# Altium schematic sheet MEHCP.SchDoc
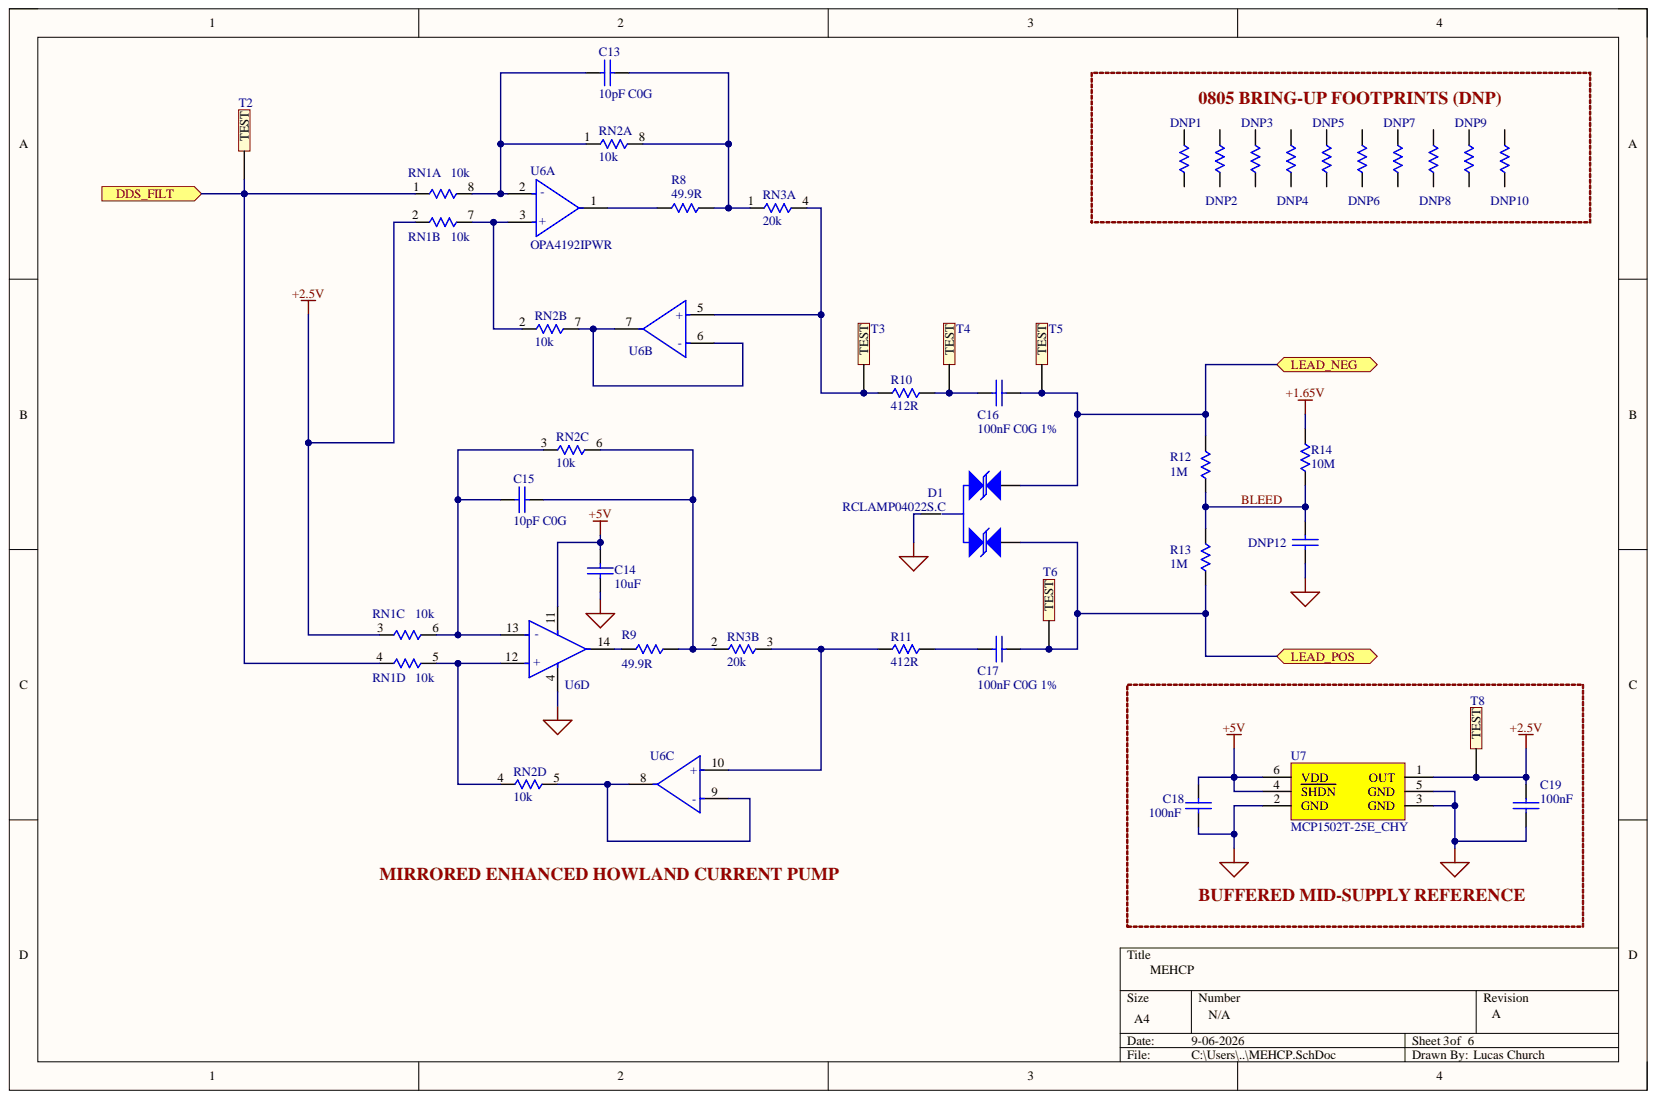
[diagram p0: MEHCP.SchDoc]

## Parts
C13  10pF C0G  [0603-CAP]
C14  10uF  [0603-CAP]
C15  10pF C0G  [0603-CAP]
C16  100nF C0G 1%  [1206-CAP]
C17  100nF C0G 1%  [1206-CAP]
C18  100nF  [0603-CAP]
C19  100nF  [0603-CAP]
D1  RCLAMP04022S.C  [SOT-23 3L]
DNP1  240R 0.1%  [0805-RES]  (value not drawn)  (no BOM)
DNP2  240R 0.1%  [0805-RES]  (value not drawn)  (no BOM)
DNP3  240R 0.1%  [0805-RES]  (value not drawn)  (no BOM)
DNP4  240R 0.1%  [0805-RES]  (value not drawn)  (no BOM)
DNP5  240R 0.1%  [0805-RES]  (value not drawn)  (no BOM)
DNP6  240R 0.1%  [0805-RES]  (value not drawn)  (no BOM)
DNP7  240R 0.1%  [0805-RES]  (value not drawn)  (no BOM)
DNP8  240R 0.1%  [0805-RES]  (value not drawn)  (no BOM)
DNP9  240R 0.1%  [0805-RES]  (value not drawn)  (no BOM)
DNP10  240R 0.1%  [0805-RES]  (value not drawn)  (no BOM)
DNP12  4.7nF C0G  [0603-CAP]  (value not drawn)  (no BOM)
R8  49.9R  [0603-RES]
R9  49.9R  [0603-RES]
R10  412R  [0603-RES]
R11  412R  [0603-RES]
R12  1M  [0603-RES]
R13  1M  [0603-RES]
R14  10M  [0603-RES]
RN1  10k  [1206 4-Resistor Network]  (parts A,B,C,D)
RN2  10k  [1206 4-Resistor Network]  (parts A,B,C,D)
RN3  20k  [0606 2-RESISTOR NETWORK]  (parts A,B)
T2  5002K  [TESTPOINT White]  (value not drawn)
T3  5002K  [TESTPOINT White]  (value not drawn)
T4  5002K  [TESTPOINT White]  (value not drawn)
T5  5002K  [TESTPOINT White]  (value not drawn)
T6  5002K  [TESTPOINT White]  (value not drawn)
T8  5002K  [TESTPOINT White]  (value not drawn)
U6  OPA4192IPWR  [FP-PW0014A-IPC_C]  (parts A,B,C,D)
U7  MCP1502T-25E_CHY  [SOT95P280X145-6N]

## Ports
DDS_FILT, LEAD_NEG, LEAD_POS

## Nets
+1.65V: R14.2
+2.5V: C19.1 RN1.2 RN1.3 T8.1 U7.1(OUT)
+5V: C14.1 C18.1 U6.11 U7.4(SHDN) U7.6(VDD)
GND: C14.2 C18.2 C19.2 D1.3 DNP12.1 U6.4 U7.2(GND) U7.3(GND) U7.5(GND)
BLEED: DNP12.2 R12.1 R13.2 R14.1
DDS_FILT: RN1.1 RN1.4 T2.1 ; port DDS_FILT
LEAD_NEG: C16.2 D1.2 R12.2 T5.1 ; port LEAD_NEG
LEAD_POS: C17.2 D1.1 R13.1 T6.1 ; port LEAD_POS
NetC13_1: C13.1 RN1.8 RN2.1 U6.2(-)
NetC13_2: C13.2 R8.2 RN2.8 RN3.1
NetC15_1: C15.1 RN1.6 RN2.3 U6.13(-)
NetC15_2: C15.2 R9.2 RN2.6 RN3.2
NetC16_1: C16.1 R10.2 T4.1
NetC17_1: C17.1 R11.2
NetDNP1_1: DNP1.1
NetDNP1_2: DNP1.2
NetDNP2_1: DNP2.1
NetDNP2_2: DNP2.2
NetDNP3_1: DNP3.1
NetDNP3_2: DNP3.2
NetDNP4_1: DNP4.1
NetDNP4_2: DNP4.2
NetDNP5_1: DNP5.1
NetDNP5_2: DNP5.2
NetDNP6_1: DNP6.1
NetDNP6_2: DNP6.2
NetDNP7_1: DNP7.1
NetDNP7_2: DNP7.2
NetDNP8_1: DNP8.1
NetDNP8_2: DNP8.2
NetDNP9_1: DNP9.1
NetDNP9_2: DNP9.2
NetDNP10_1: DNP10.1
NetDNP10_2: DNP10.2
NetR8_1: R8.1 U6.1
NetR9_1: R9.1 U6.14
NetR10_1: R10.1 RN3.4 T3.1 U6.5(+)
NetR11_1: R11.1 RN3.3 U6.10(+)
NetRN1_5: RN1.5 RN2.4 U6.12(+)
NetRN1_7: RN1.7 RN2.2 U6.3(+)
NetRN2_5: RN2.5 U6.8 U6.9(-)
NetRN2_7: RN2.7 U6.6(-) U6.7

## Library data of the parts
C13 C15 = CGA3E2C0G1H472J080AA: CAP CER 10PF 50V C0G 0603; Manufacturer 1: Murata; Manufacturer Part Number 1: CC0603JRNPO9BN100; Database Table Name: Ceramic 0603$; Tech#: SMT; Component Kind: Standard; Detailed Description: CAP CER 10PF 50V C0G 0603; Price(100): 0.1434; Price(250): 0.10756; Supplier 1: DigiKey; Supplier 2: Mouser; Supplier Part Number 1: 311-1058-1-ND; Supplier Part Number 2: 810-CGA3E2C0G1H472J; Library Name: SGL_Capacitors.DBLib
C14 = CGA3E2C0G1H472J080AA: CAP CER 10uF 6.3V X5R 0603; Manufacturer 1: Murata Electronics; Manufacturer Part Number 1: GRM188Z71A106KA73D; Database Table Name: Ceramic 0603$; Detailed Description: CAP CER 100 NF 50V X7R 0603; Tech#: SMT; Component Kind: Standard; Price(100): 0.1434; Price(250): 0.10756; Supplier 1: DigiKey; Supplier 2: Mouser; Supplier Part Number 1: 490-GRM188Z71A106KA73DCT-ND; Supplier Part Number 2: 810-CGA3E2C0G1H472J; Library Name: SGL_Capacitors.DBLib
C16 C17 = GRM31C5C1H104JA01L: CAP CER 0.1UF 1% 50V C0G/NP0 1206; Manufacturer 1: Murata; Manufacturer Part Number 1: GRT31C5C1H104FA02L; Database Table Name: Ceramic 1206$; Tech#: SMT; Component Kind: Standard; Detailed Description: CAP CER 0.1UF 1% 50V C0G/NP0 1206; Price(100): 0.2317; Supplier 1: DigiKey; Supplier 2: Mouser; Supplier Part Number 1: 490-GRT31C5C1H104FA02LCT-ND; Supplier Part Number 2: 81-GRM31C5C1H104JA1L; Library Name: SGL_Capacitors.DBLib
C18 C19 = CGA3E2C0G1H472J080AA: CAP CER 100 NF 50V X7R 0603; Manufacturer 1: YAGEO; Manufacturer Part Number 1: CC0603KRX7R9BB104; Database Table Name: Ceramic 0603$; Tech#: SMT; Component Kind: Standard; Detailed Description: CAP CER 100 NF 50V X7R 0603; Price(100): 0.1434; Price(250): 0.10756; Supplier 1: DigiKey; Supplier 2: Mouser; Supplier Part Number 1: 311-1344-1-ND; Supplier Part Number 2: 810-CGA3E2C0G1H472J; Library Name: SGL_Capacitors.DBLib
DNP1-DNP10 = 240R 0.1%: RES SMD 240 OHM 0.1% 1/8W 0805; Manufacturer 1: Susumu; Manufacturer Part Number 1: RG2012P-241-B-T5; Database Table Name: 0805-tenth%$; Tech#: SMT; Detailed Description: RES SMD 240 OHM 0.1% 1/8W 0805; Price(100): 0.149; Simulation: Resistor; Supplier 1: Mouser; Supplier 2: DigiKey; Supplier Part Number 1: 754-RG2012P-241-BT5; Supplier Part Number 2: RG20P240BCT-ND; Library Name: SGL_Resistives.DBLib
DNP12 = CGA3E2C0G1H472J080AA: CAP CER 4700PF 50V C0G 0603; Manufacturer 1: Murata; Manufacturer Part Number 1: GRM1885C1H472JA01D; Database Table Name: Ceramic 0603$; Tech#: SMT; Detailed Description: CAP CER 4700PF 50V C0G 0603; Price(100): 0.1434; Price(250): 0.10756; Supplier 1: DigiKey; Supplier 2: Mouser; Supplier Part Number 1: 490-9669-1-ND; Supplier Part Number 2: 810-CGA3E2C0G1H472J; Library Name: SGL_Capacitors.DBLib
R8 R9 = 4.99K 0603: RES SMD 49.9OHM 0.1% 1/10W 0603; Manufacturer 1: Yageo; Manufacturer Part Number 1: RT0603BRD0749R9L; Database Table Name: 0603$; Tech#: SMT; Component Kind: Standard; Detailed Description: RES SMD 49.9OHM 0.1% 1/10W 0603; Price(100): 0.023; Simulation: Resistor; Supplier 1: DigiKey; Supplier 2: Mouser; Supplier Part Number 1: YAG1672CT-ND; Supplier Part Number 2: 754-RR0816P-4991D68H; Library Name: SGL_Resistives.DBLib
R10 R11 = 4.99K 0603: RES SMD 412OHM 0.1% 1/10W 0603; Manufacturer 1: YAGEO; Manufacturer Part Number 1: RT0603BRD07412RL; Database Table Name: 0603$; Tech#: SMT; Component Kind: Standard; Detailed Description: RES SMD 412OHM 0.1% 1/10W 0603; Price(100): 0.023; Simulation: Resistor; Supplier 1: DigiKey; Supplier 2: Mouser; Supplier Part Number 1: YAG1659CT-ND; Supplier Part Number 2: 754-RR0816P-4991D68H; Library Name: SGL_Resistives.DBLib
R12 R13 = 4.99K 0603: RES SMD 1MOHM 0.1% 1/10W 0603; Manufacturer 1: YAGEO; Manufacturer Part Number 1: RT0603BRD071ML; Database Table Name: 0603$; Detailed Description: RES SMD 1OHM 0.1% 1/10W 0603; Tech#: SMT; Component Kind: Standard; Price(100): 0.023; Simulation: Resistor; Supplier 1: DigiKey; Supplier 2: Mouser; Supplier Part Number 1: YAG4498CT-ND; Supplier Part Number 2: 754-RR0816P-4991D68H; Library Name: SGL_Resistives.DBLib
R14 = 4.99K 0603: RES SMD 10MOHM 1% 1/10W 0603; Manufacturer 1: YAGEO; Manufacturer Part Number 1: RC0603FR-0710ML; Database Table Name: 0603$; Tech#: SMT; Component Kind: Standard; Detailed Description: RES SMD 10MOHM 1% 1/10W 0603; Price(100): 0.023; Simulation: Resistor; Supplier 1: DigiKey; Supplier 2: Mouser; Supplier Part Number 1: 311-10.0MHRCT-ND; Supplier Part Number 2: 754-RR0816P-4991D68H; Library Name: SGL_Resistives.DBLib
RN1 RN2 = ACASA1002S1002P1AT: 4 resistor, resistor network in a 8 pin DIP package; Component Kind: Standard; Library Name: BioZ.SchLib
RN3 = ACASN1002S1002P1AT: 2 resistor, resistor network, 10k/10k 0.1% abs. and 0.05% rel., 0606, 25ppm; Component Kind: Standard; Library Name: BioZ.SchLib
T2-T6 T8 = 5002K: PC TEST POINT MINIATURE WHITE; Manufacturer 1: Keystone Electronics; Database Table Name: Testpoints$; Detailed Description: Connector, TestPoint White; Location: F1-33; Tech#: T/H; Component Kind: Standard; Manufacturer Part Number 1: 5002K; Price(1): 0.56; Price(10): 0.506; Price(100): 0.3541; Supplier 1: DigiKey; Supplier 2: Mouser; Supplier Part Number 1: 36-5002-ND; Supplier Part Number 2: 534-5002; Library Name: SGL_Connectors.DBLib
U6 = CMP-04895-000439-1: IC OPAMP GP 4 CIRCUIT 14TSSOP; Gain Bandwidth Product: 10MHz; Number of Channels: 4; Case/Package: TSSOP; Component Kind: Standard; Lead Free: Lead Free; Max Operating Temperature: 125°C; Min Operating Temperature: -40°C; RoHS Compliant: Yes; Library Name: BioZ.SchLib
U7 = MCP1502T-25E_CHY: Series Voltage Reference IC Fixed 2.5V V +/-0.1% 20 mA SOT-23-6; Manufacturer_Name: Microchip; Manufacturer_Part_Number: MCP1502T-25E/CHY; Geometry.Height: 1.45mm; Component Kind: Standard; Mouser Part Number: 579-MCP1502T-25E/CHY; Mouser Price/Stock: https://www.mouser.co.uk/ProductDetail/Microchip-Technology/MCP1502T-25E-CHY?qs=W%2FMpXkg%252BdQ4EOhKykk%2F7dw%3D%3D; Library Name: BioZ.SchLib
D1 = RCLAMP04022S.C: Component Kind: Standard; Library Name: BioZ.SchLib
